# Altium schematic sheet Top.SchDoc("Top Schematic")
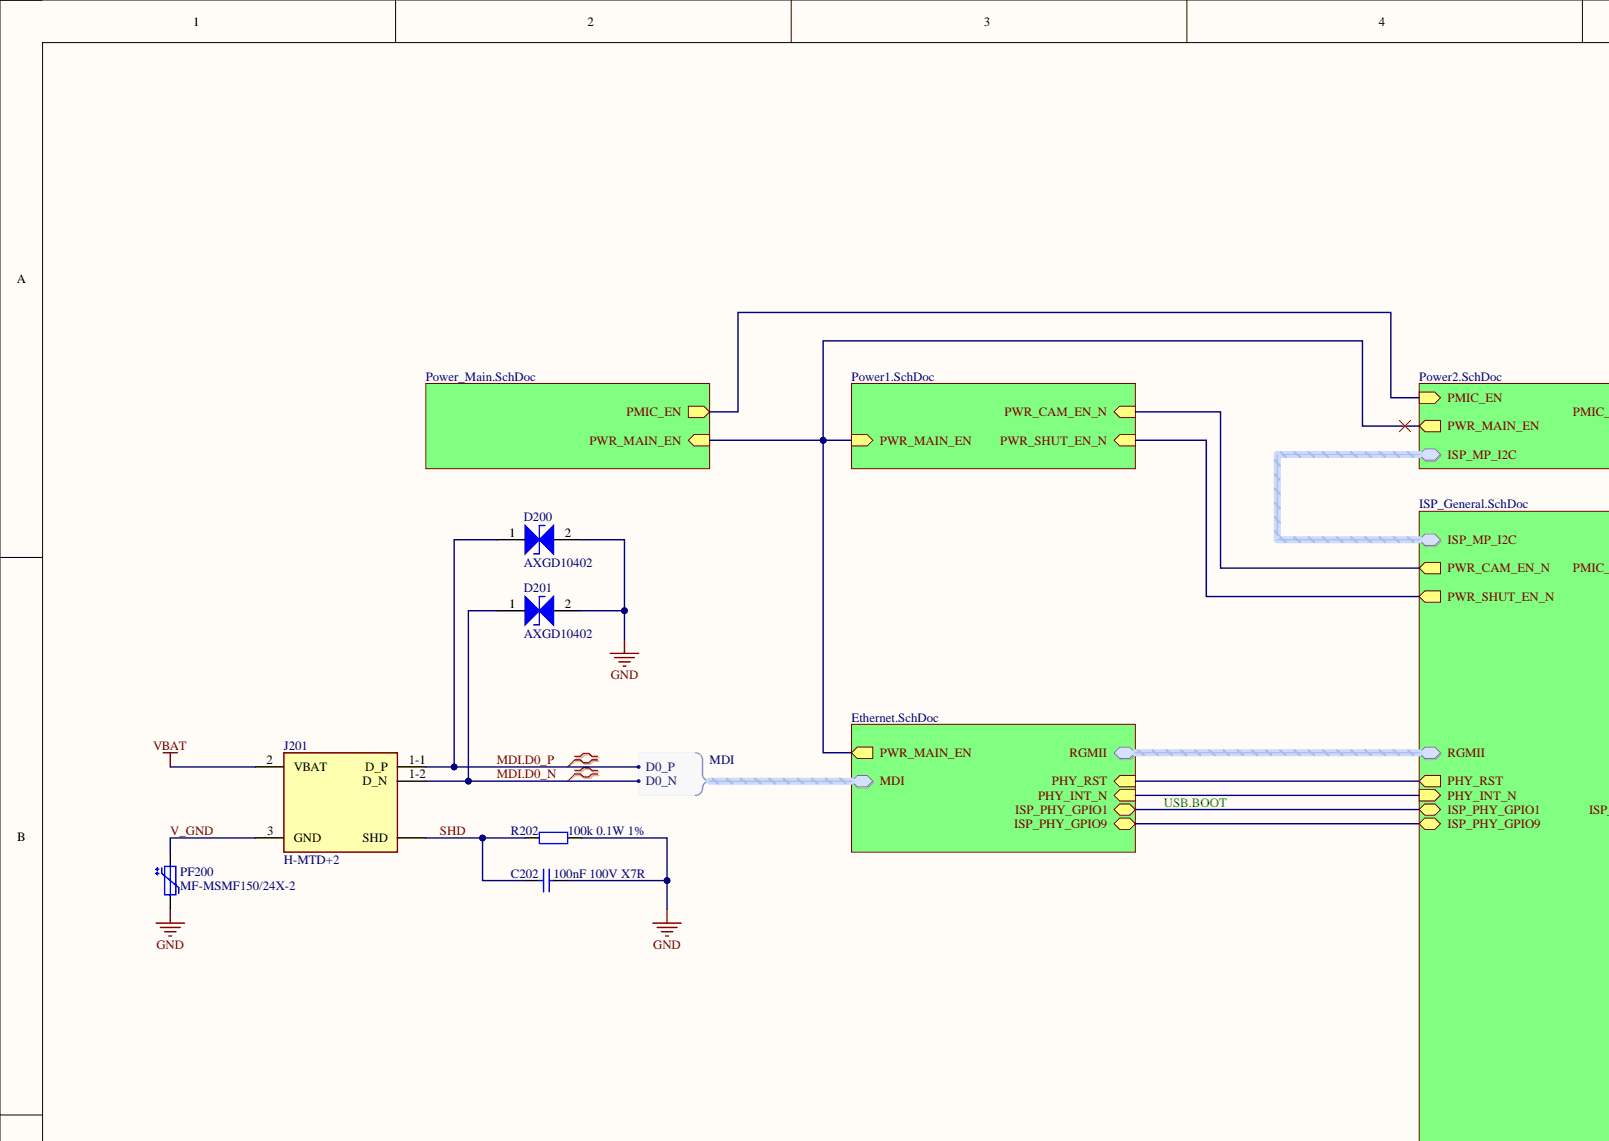
[diagram p0: Top.SchDoc, top left]
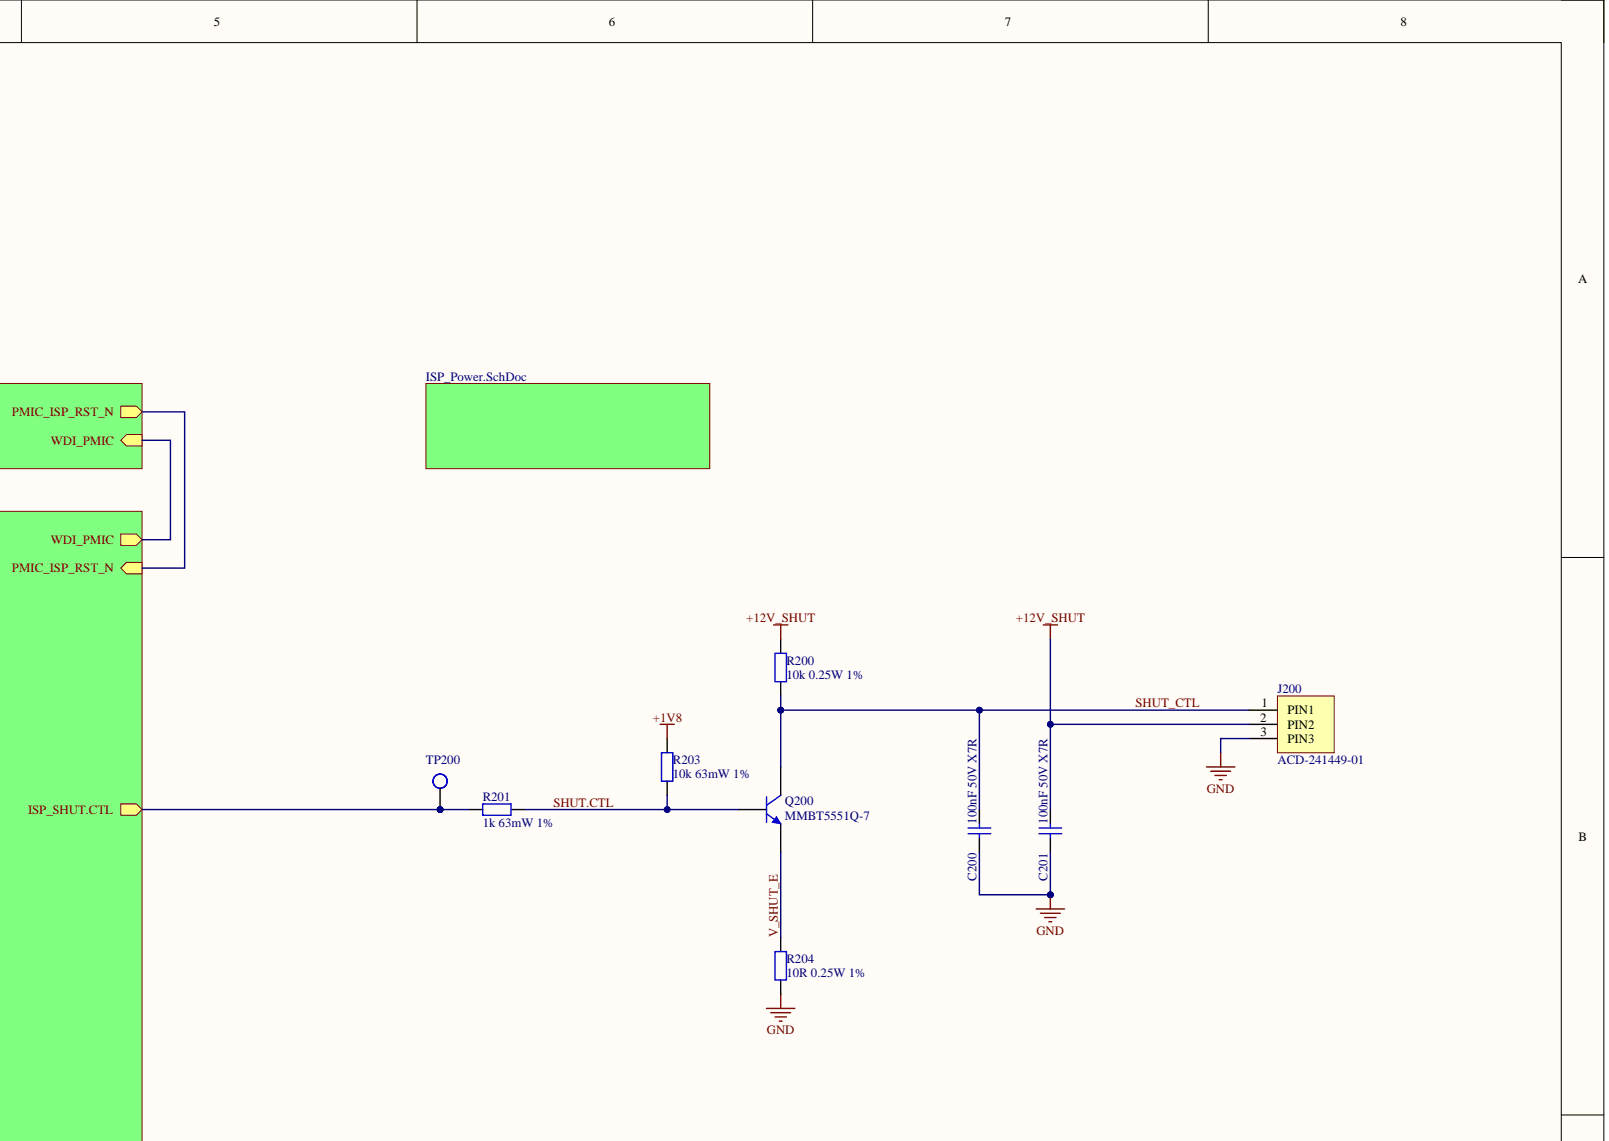
[diagram p1: Top.SchDoc, top right]
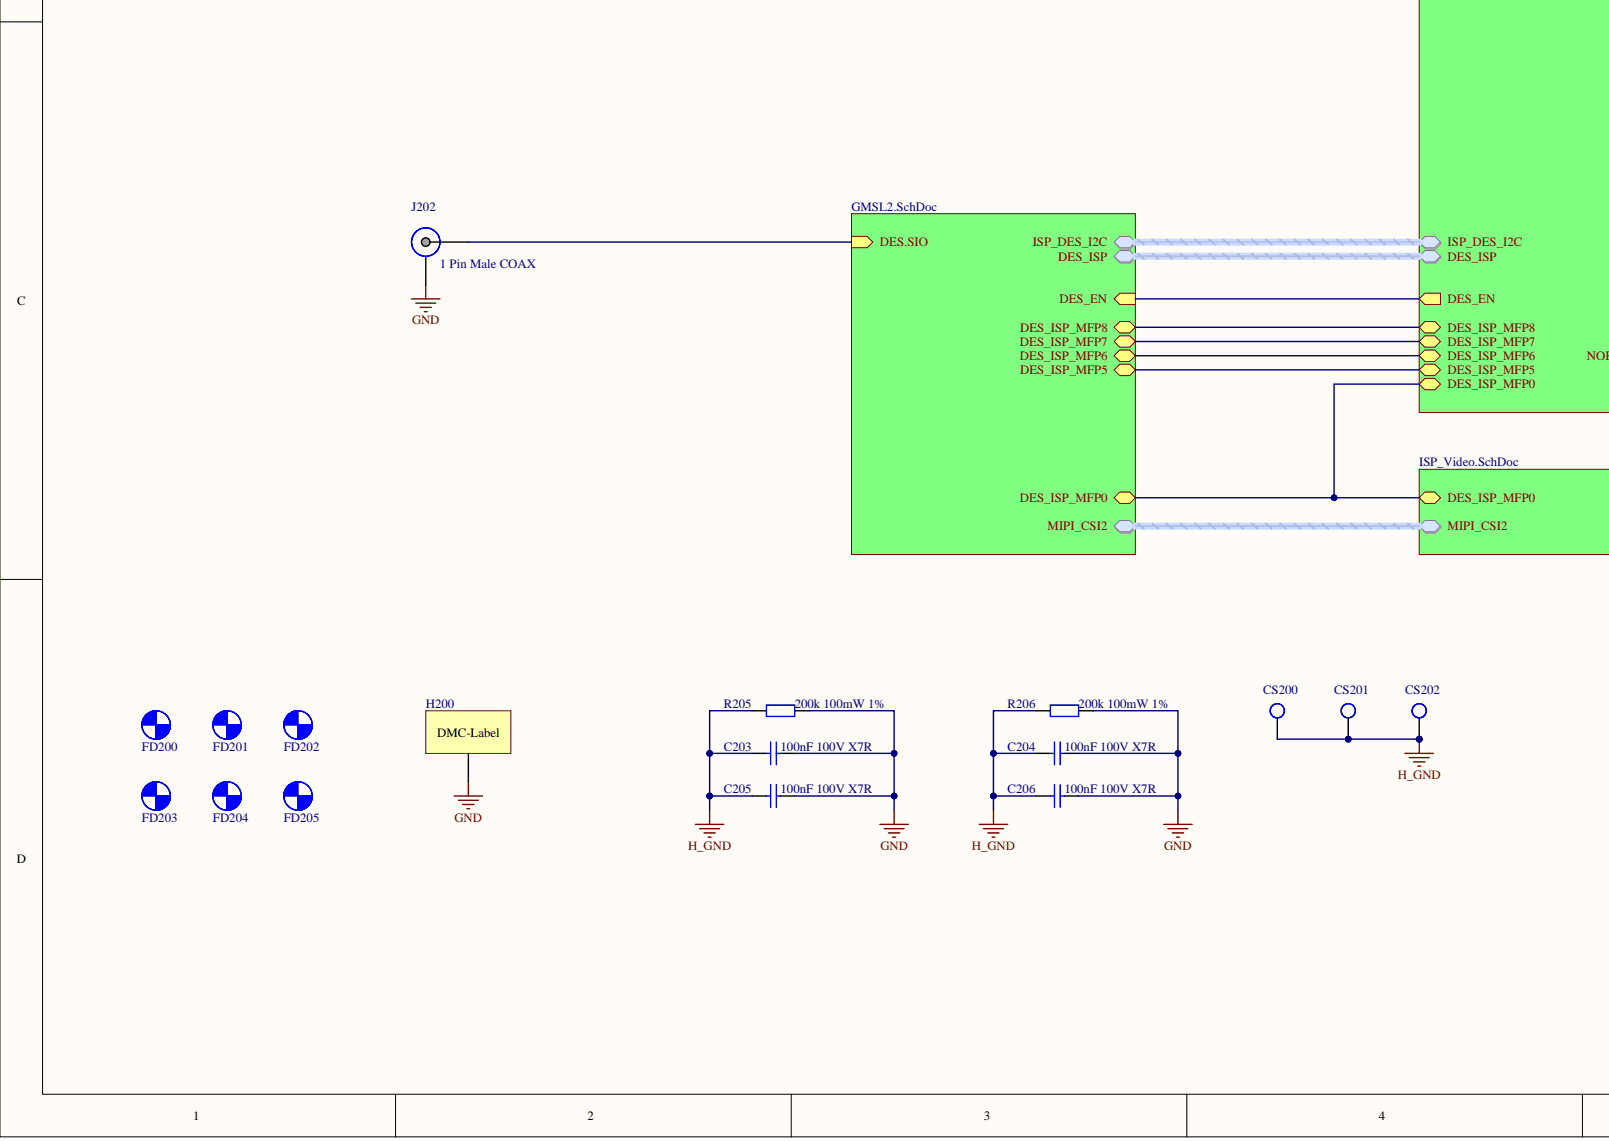
[diagram p2: Top.SchDoc, bottom left]
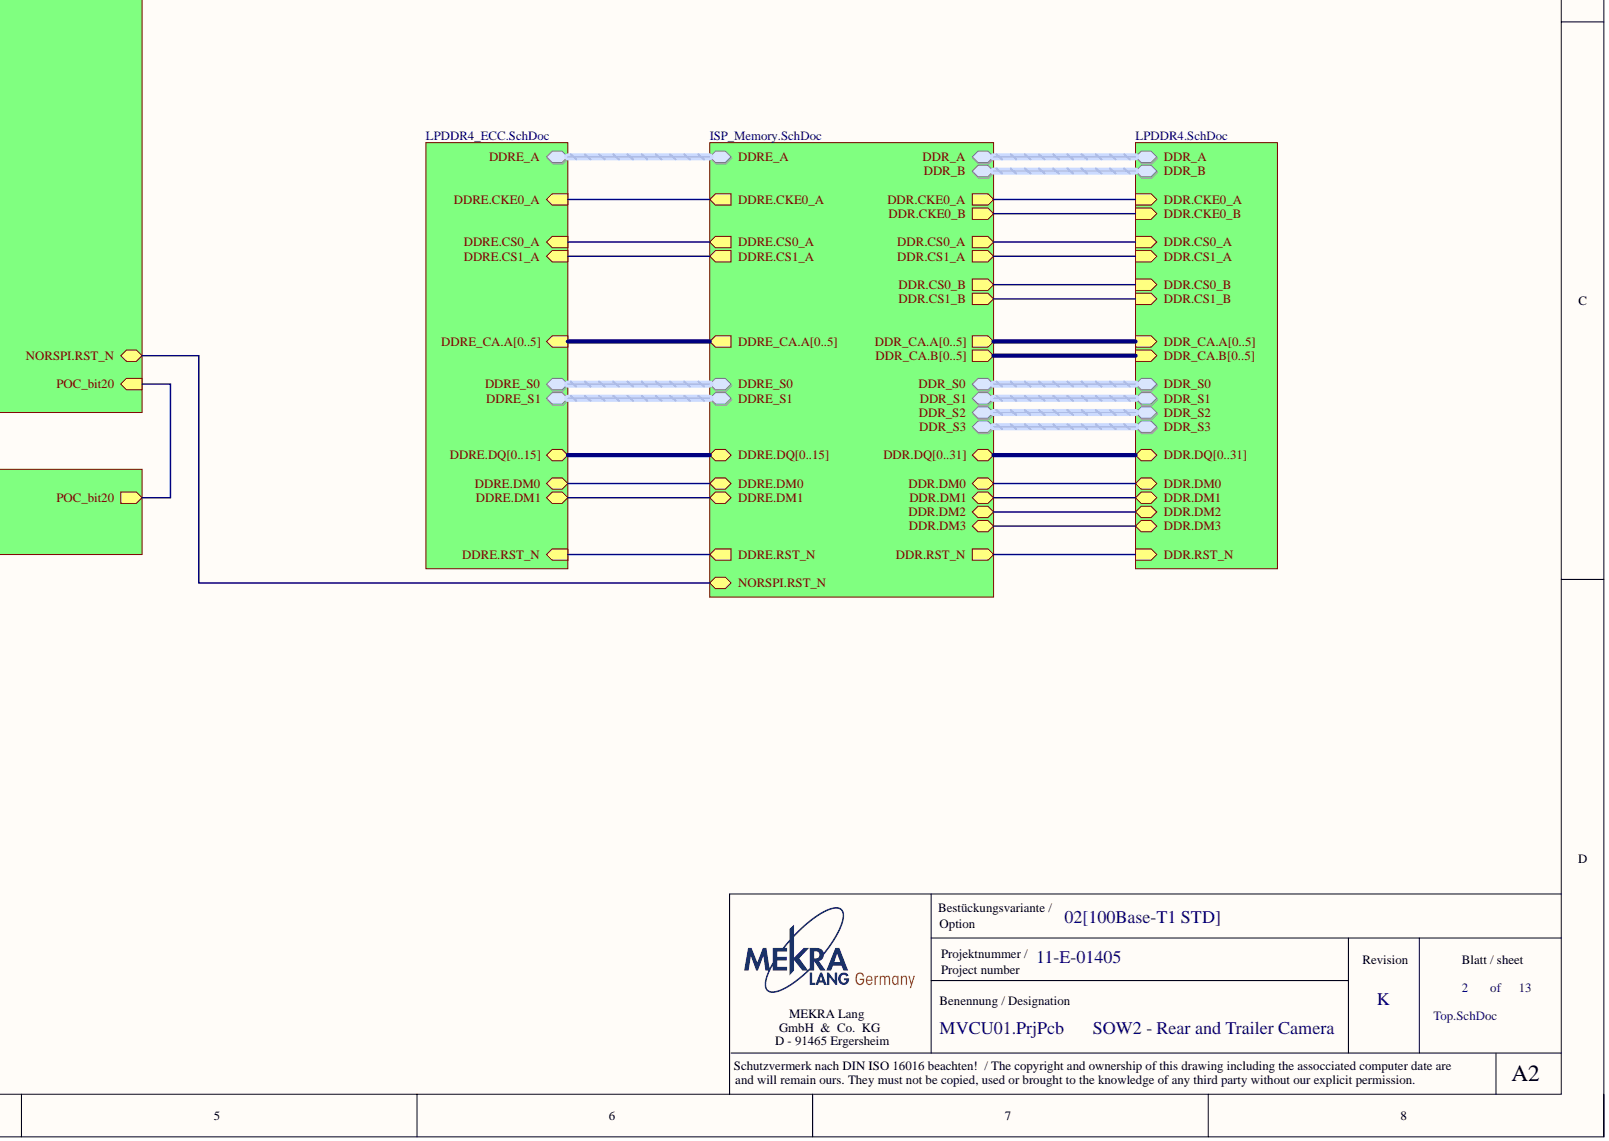
[diagram p3: Top.SchDoc, bottom right]

## Parts
C200  100nF 50V X7R  [C_0402_H]
C201  100nF 50V X7R  [C_0402_H]
C202  100nF 100V X7R  [C_0805_H]
C203  100nF 100V X7R  [C_0805_H]
C204  100nF 100V X7R  [C_0805_H]
C205  100nF 100V X7R  [C_0805_H]
C206  100nF 100V X7R  [C_0805_H]
CS200  CS  [CS_SSMD011032007]
CS201  CS  [CS_SSMD011032007]
CS202  CS  [CS_SSMD011032007]
D200  AXGD10402  [D0402_H]
D201  AXGD10402  [D0402_H]
FD200  FD  [FD_1mm 3D]  (no BOM)
FD201  FD  [FD_1mm 3D]  (no BOM)
FD202  FD  [FD_1mm 3D]  (no BOM)
FD203  FD  [FD_1mm 3D]  (no BOM)
FD204  FD  [FD_1mm 3D]  (no BOM)
FD205  FD  [FD_1mm 3D]  (no BOM)
H200  DMC-Label  [Label_6x6]  (no BOM)
J200  ACD-241449-01  [CON_ACD-241449-01]
J201  H-MTD+2  [CON_H-MTD+2_APTIV_Pressfit]  (no BOM)
J202  1 Pin Male COAX  [CON_FAKRA_ROSENB._MB_495]
PF200  MF-MSMF150/24X-2  [PF1812_M]
Q200  MMBT5551Q-7  [SOT23F_H]
R200  10k 0.25W 1%  [R_0805_H]
R201  1k 63mW 1%  [R_0402_H]
R202  100k 0.1W 1%  [R_0603_H]
R203  10k 63mW 1%  [R_0402_H]
R204  10R 0.25W 1%  [R_0805_H]
R205  200k 100mW 1%  [R_0603_H]
R206  200k 100mW 1%  [R_0603_H]
TP200  TP  [TP_0.8mm_3D]  (no BOM)

## Nets
+1V8: R203.2
+12V_SHUT: C201.2 J200.2 R200.1
GND: C200.1 C201.1 C202.1 C203.1 C204.1 C205.1 C206.1 D200.2 D201.2 H200.1 J200.3 J202.SHD PF200.1 R202.1 R204.2 R205.1 R206.1
H_GND: C203.2 C204.2 C205.2 C206.2 CS200.1 CS201.1 CS202.1 R205.2 R206.2
VBAT: J201.2(VBAT)
MDI.D0_N: D201.1 J201.1-2(D_N)
MDI.D0_P: D200.1 J201.1-1(D_P)
SHD: C202.2 J201.SHD R202.2
SHUT.CTL: Q200.1 R201.1 R203.1
SHUT_CTL: C200.2 J200.1 Q200.3 R200.2
V_GND: J201.3(GND) PF200.2
V_SHUT_E: Q200.2 R204.1
NetJ202_1: J202.1
NetR201_2: R201.2 TP200.1
DDR.CKE0_A:
DDR.CKE0_B:
DDR.CS0_A:
DDR.CS0_B:
DDR.CS1_A:
DDR.CS1_B:
DDR.DM0:
DDR.DM1:
DDR.DM2:
DDR.DM3:
DDR.DQ0:
DDR.DQ1:
DDR.DQ2:
DDR.DQ3:
DDR.DQ4:
DDR.DQ5:
DDR.DQ6:
DDR.DQ7:
DDR.DQ8:
DDR.DQ9:
DDR.DQ10:
DDR.DQ11:
DDR.DQ12:
DDR.DQ13:
DDR.DQ14:
DDR.DQ15:
DDR.DQ16:
DDR.DQ17:
DDR.DQ18:
DDR.DQ19:
DDR.DQ20:
DDR.DQ21:
DDR.DQ22:
DDR.DQ23:
DDR.DQ24:
DDR.DQ25:
DDR.DQ26:
DDR.DQ27:
DDR.DQ28:
DDR.DQ29:
DDR.DQ30:
DDR.DQ31:
DDR.RST_N:
DDR_A.CK_N:
DDR_A.CK_P:
DDR_B.CK_N:
DDR_B.CK_P:
DDR_CA.A0:
DDR_CA.A1:
DDR_CA.A2:
DDR_CA.A3:
DDR_CA.A4:
DDR_CA.A5:
DDR_CA.B0:
DDR_CA.B1:
DDR_CA.B2:
DDR_CA.B3:
DDR_CA.B4:
DDR_CA.B5:
DDR_S0.DQ_N:
DDR_S0.DQ_P:
DDR_S1.DQ_N:
DDR_S1.DQ_P:
DDR_S2.DQ_N:
DDR_S2.DQ_P:
DDR_S3.DQ_N:
DDR_S3.DQ_P:
DDRE.CKE0_A:
DDRE.CS0_A:
DDRE.CS1_A:
DDRE.DM0:
DDRE.DM1:
DDRE.DQ0:
DDRE.DQ1:
DDRE.DQ2:
DDRE.DQ3:
DDRE.DQ4:
DDRE.DQ5:
DDRE.DQ6:
DDRE.DQ7:
DDRE.DQ8:
DDRE.DQ9:
DDRE.DQ10:
DDRE.DQ11:
DDRE.DQ12:
DDRE.DQ13:
DDRE.DQ14:
DDRE.DQ15:
DDRE.RST_N:
DDRE_A.CK_N:
DDRE_A.CK_P:
DDRE_CA.A0:
DDRE_CA.A1:
DDRE_CA.A2:
DDRE_CA.A3:
DDRE_CA.A4:
DDRE_CA.A5:
DDRE_S0.DQ_N:
DDRE_S0.DQ_P:
DDRE_S1.DQ_N:
DDRE_S1.DQ_P:
DES_EN:
DES_ISP.MFP1:
DES_ISP.MFP2:
DES_ISP_MFP0:
DES_ISP_MFP5:
DES_ISP_MFP6:
DES_ISP_MFP7:
DES_ISP_MFP8:
ISP_DES_I2C.CLK:
ISP_DES_I2C.DAT:
ISP_MP_I2C.CLK:
ISP_MP_I2C.DAT:
ISP_PHY_GPIO1:
ISP_PHY_GPIO9:
MIPI_CSI2.CK_N:
MIPI_CSI2.CK_P:
MIPI_CSI2.D0_N:
MIPI_CSI2.D0_P:
MIPI_CSI2.D1_N:
MIPI_CSI2.D1_P:
MIPI_CSI2.D2_N:
MIPI_CSI2.D2_P:
MIPI_CSI2.D3_N:
MIPI_CSI2.D3_P:
NORSPI.RST_N:
PHY_INT_N:
PHY_RST:
PMIC_EN:
PMIC_ISP_RST_N:
POC_bit20:
PWR_CAM_EN_N:
PWR_MAIN_EN:
PWR_SHUT_EN_N:
RGMII.MDC:
RGMII.MDIO:
RGMII.RX_CTL:
RGMII.RXC:
RGMII.RXD0:
RGMII.RXD1:
RGMII.RXD2:
RGMII.RXD3:
RGMII.TX_CTL:
RGMII.TXC:
RGMII.TXD0:
RGMII.TXD1:
RGMII.TXD2:
RGMII.TXD3:
WDI_PMIC:

## Library data of the parts
C200-C206 = C: Ceramic Capacitor; AEC-Q: True; Component Kind: Standard; Library Name: C.SchLib; txtDesignator: C
  C200 C201: Package: 0402; Value: 100nF 50V X7R
  C202-C206: Package: 0805; Value: 100nF 100V X7R
CS200-CS202 = CS: EMC Contact Spring; Manufacturer: Compelma; ManufacturerPartNo: SSMD011032007; AEC-Q: False; Component Kind: Standard; Package: 1.1x3.2x0.7; txtDesignator: CS; Library Name: MEKRA.IntLib
D200 D201 = D_ESD Diode: ESD Suppressor; Manufacturer: Littlefuse; AEC-Q: True; txtDesignator: D; Value: 0.04pF; Component Kind: Standard; Library Name: Schlib1.SchLib; ManufacturerPartNo: AXGD10402; Package: 0402
FD200-FD205 = FD: Fiducial Mark - Passermarke; txtDesignator: FD; Library Name: MEKRA.IntLib
H200 = Label: Data Matrix Code; txtDesignator: H; Library Name: MEKRA.IntLib
J200 = CON_3p: Connector 3 Pin; Manufacturer: Samtec; AEC-Q: True; txtDesignator: J; Component Kind: Standard; ManufacturerPartNo: ACD-241449-01; Package: HTSW-103-14-T-S-P-TR; Library Name: MEKRA.IntLib
J201 = CON_H-MTD+2: H-MTD+2 Connector with Supply and Single Pair Ethernet with Shield; txtDesignator: J; Library Name: MEKRA.IntLib
J202 = CON_COAX: Manufacturer: ROSENBERGER; ManufacturerPartNo: 59S13N-40MT5; txtDesignator: J; Component Kind: Standard; Description: 1 Pin Male COAX; Library Name: MEKRA.IntLib
PF200 = Polyfuse: Manufacturer: Bourns; AEC-Q: True; txtDesignator: Q; Component Kind: Standard; Library Name: Schlib1.SchLib; ManufacturerPartNo: MF-MSMF150/24X-2; Package: 1812
Q200 = Q_NPN: Transistor NPN; Manufacturer: Diodes Incorporated; AEC-Q: True; txtDesignator: Q; Component Kind: Standard; Library Name: Schlib1.SchLib; ManufacturerPartNo: MMBT5551Q-7; Package: SOT23-3
R200-R206 = R: Resistor; AEC-Q: True; Component Kind: Standard; Library Name: R.SchLib; txtDesignator: R
  R200: Package: 0805; Value: 10k 0.25W 1%
  R201: Package: 0402; Value: 1k 63mW 1%
  R202: Package: 0603; Value: 100k 0.1W 1%
  R203: Package: 0402; Value: 10k 63mW 1%
  R204: Package: 0805; Value: 10R 0.25W 1%
  R205 R206: Package: 0603; Value: 200k 100mW 1%
TP200 = TP: Test Pad; Library Name: TP.SchLib; txtDesignator: TP
